# Altium schematic sheet [16] - PS BANKS 500-502.SchDoc("[16] - PS BANKS 500-502")
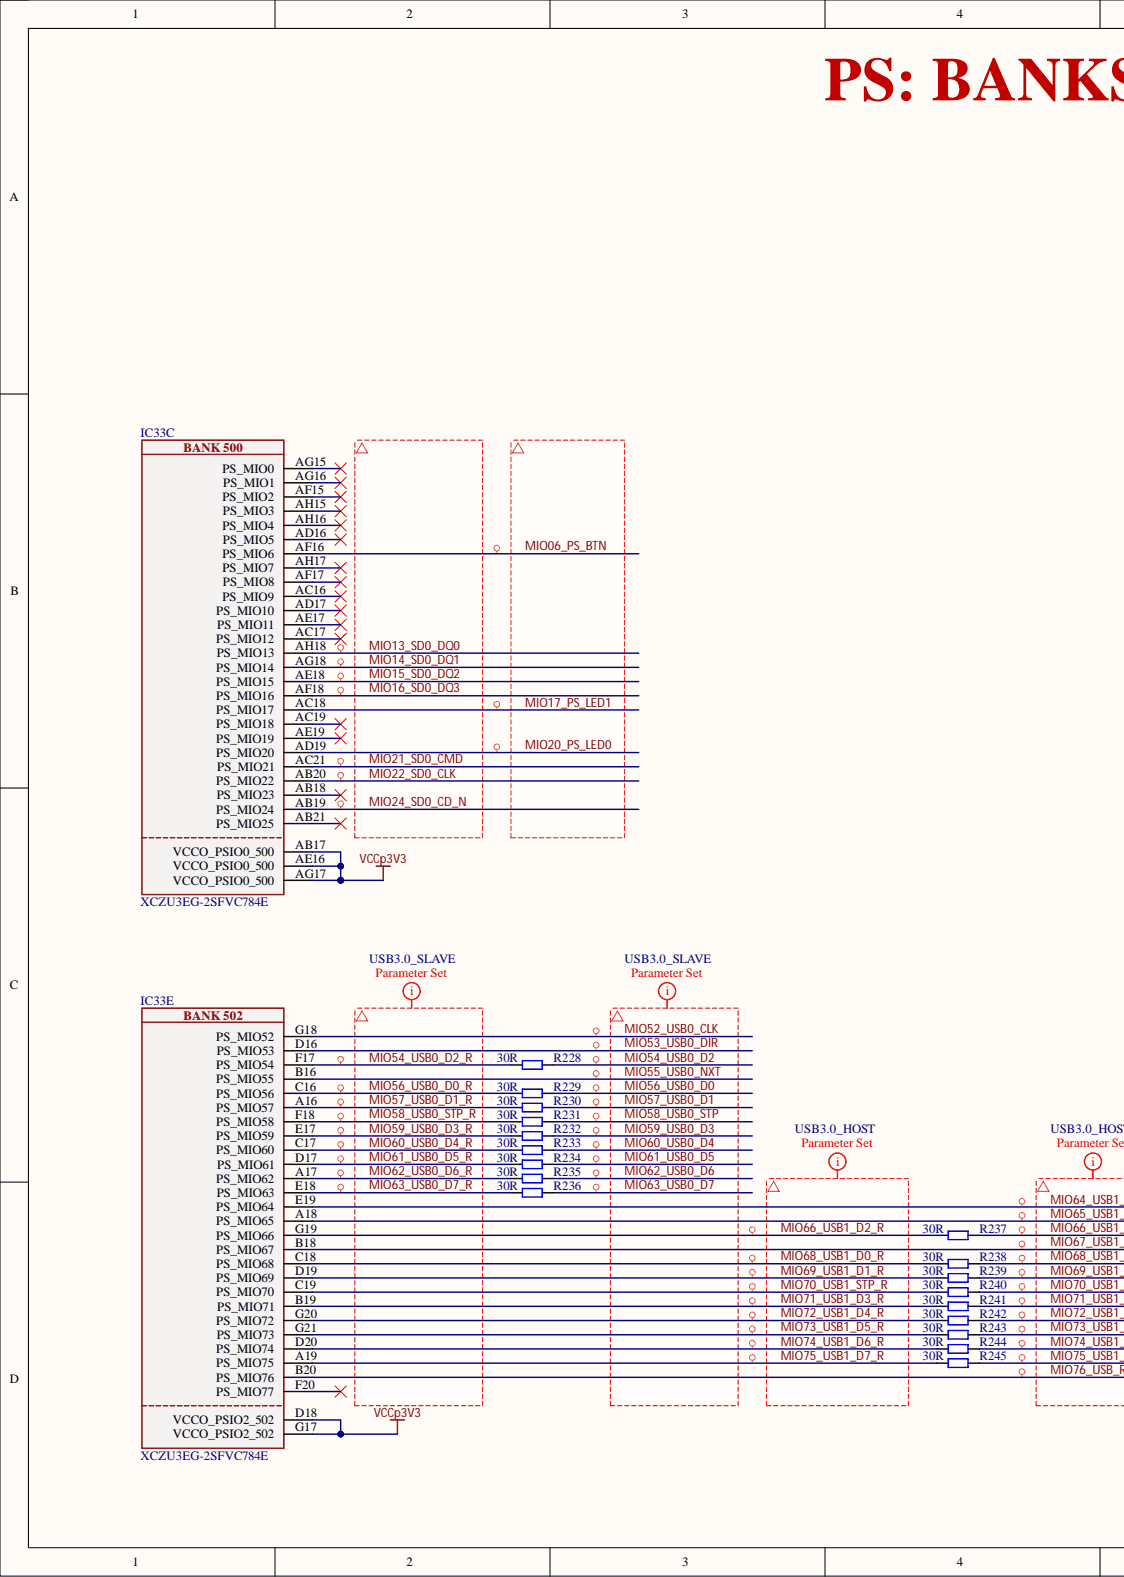
[diagram p0: [16] - PS BANKS 500-502.SchDoc, left]
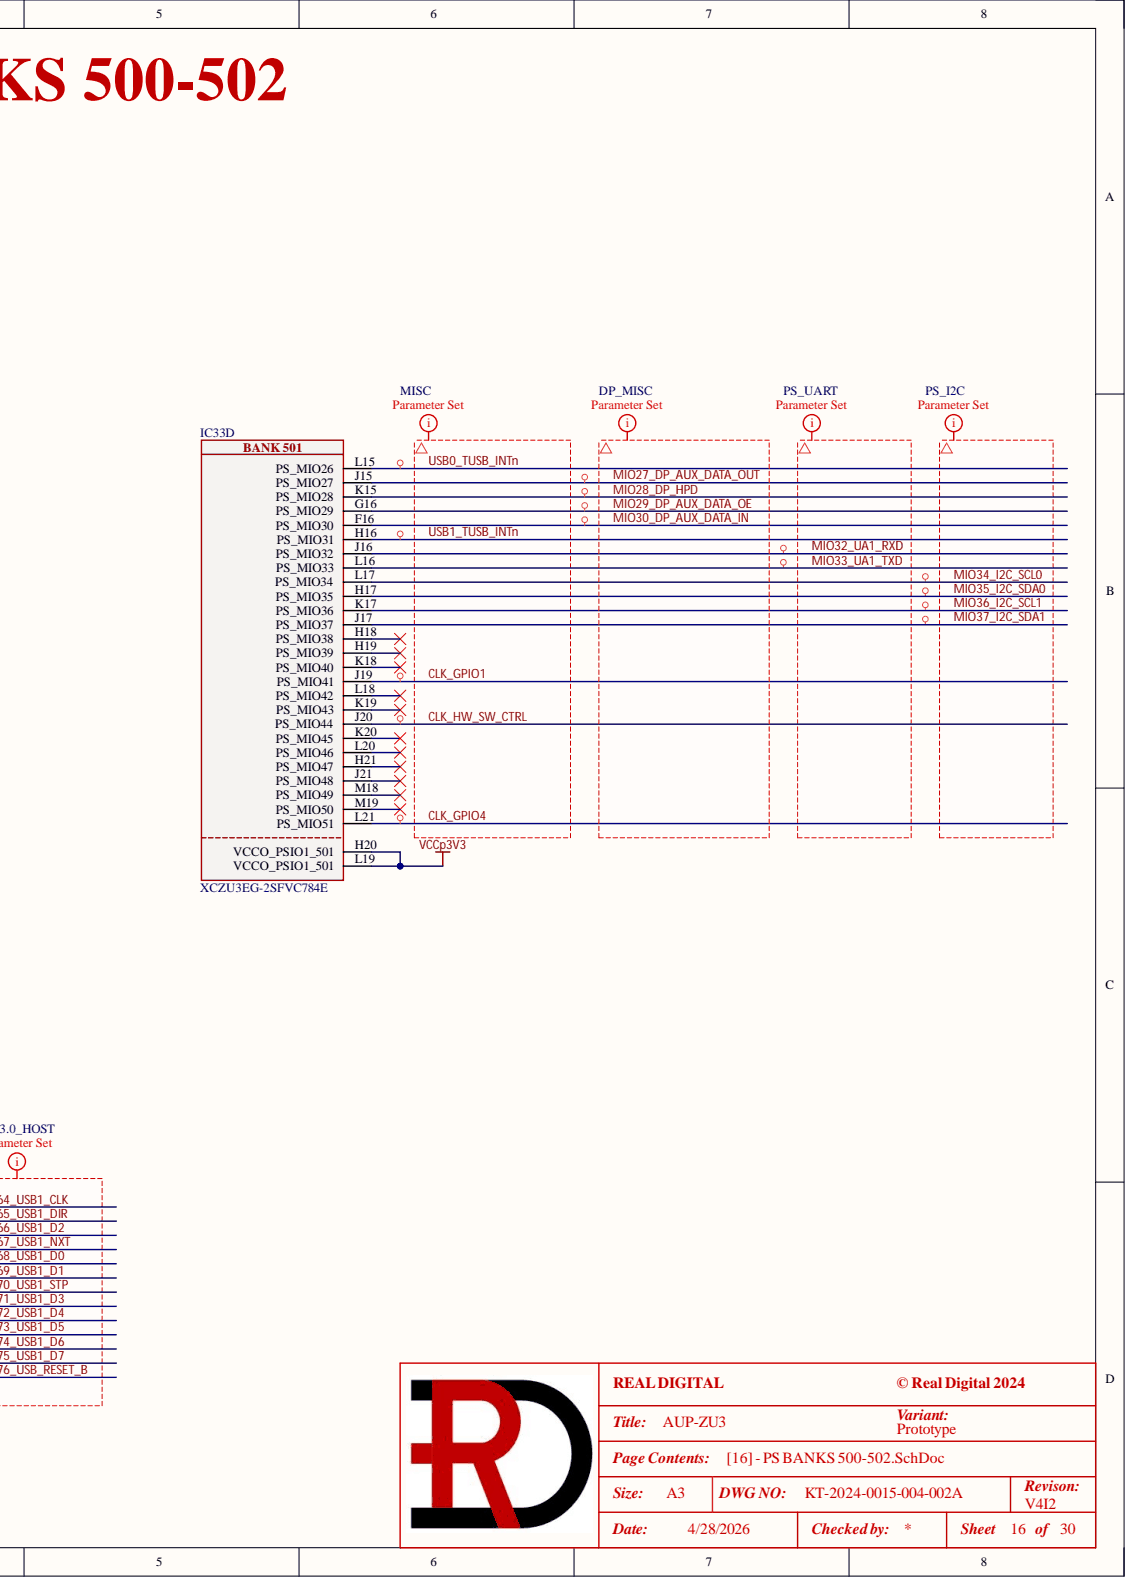
[diagram p1: [16] - PS BANKS 500-502.SchDoc, right]

## Parts
IC33  XCZU3EG-2SFVC784E  [SFVC784 - AMD]  (parts C,D,E)
R228  30R  [R0201]
R229  30R  [R0201]
R230  30R  [R0201]
R231  30R  [R0201]
R232  30R  [R0201]
R233  30R  [R0201]
R234  30R  [R0201]
R235  30R  [R0201]
R236  30R  [R0201]
R237  30R  [R0201]
R238  30R  [R0201]
R239  30R  [R0201]
R240  30R  [R0201]
R241  30R  [R0201]
R242  30R  [R0201]
R243  30R  [R0201]
R244  30R  [R0201]
R245  30R  [R0201]

## Nets
VCCp3V3: IC33.AB17(VCCO_PSIO0_500) IC33.AE16(VCCO_PSIO0_500) IC33.AG17(VCCO_PSIO0_500) IC33.D18(VCCO_PSIO2_502) IC33.G17(VCCO_PSIO2_502) IC33.H20(VCCO_PSIO1_501) IC33.L19(VCCO_PSIO1_501)
CLK_GPIO1: IC33.J19(PS_MIO41)
CLK_GPIO4: IC33.L21(PS_MIO51)
CLK_HW_SW_CTRL: IC33.J20(PS_MIO44)
MIO06_PS_BTN: IC33.AF16(PS_MIO6)
MIO13_SD0_DQ0: IC33.AH18(PS_MIO13)
MIO14_SD0_DQ1: IC33.AG18(PS_MIO14)
MIO15_SD0_DQ2: IC33.AE18(PS_MIO15)
MIO16_SD0_DQ3: IC33.AF18(PS_MIO16)
MIO17_PS_LED1: IC33.AC18(PS_MIO17)
MIO20_PS_LED0: IC33.AD19(PS_MIO20)
MIO21_SD0_CMD: IC33.AC21(PS_MIO21)
MIO22_SD0_CLK: IC33.AB20(PS_MIO22)
MIO24_SD0_CD_N: IC33.AB19(PS_MIO24)
MIO27_DP_AUX_DATA_OUT: IC33.J15(PS_MIO27)
MIO28_DP_HPD: IC33.K15(PS_MIO28)
MIO29_DP_AUX_DATA_OE: IC33.G16(PS_MIO29)
MIO30_DP_AUX_DATA_IN: IC33.F16(PS_MIO30)
MIO32_UA1_RXD: IC33.J16(PS_MIO32)
MIO33_UA1_TXD: IC33.L16(PS_MIO33)
MIO34_I2C_SCL0: IC33.L17(PS_MIO34)
MIO35_I2C_SDA0: IC33.H17(PS_MIO35)
MIO36_I2C_SCL1: IC33.K17(PS_MIO36)
MIO37_I2C_SDA1: IC33.J17(PS_MIO37)
MIO52_USB0_CLK: IC33.G18(PS_MIO52)
MIO53_USB0_DIR: IC33.D16(PS_MIO53)
MIO54_USB0_D2: R228.2
MIO54_USB0_D2_R: IC33.F17(PS_MIO54) R228.1
MIO55_USB0_NXT: IC33.B16(PS_MIO55)
MIO56_USB0_D0: R229.2
MIO56_USB0_D0_R: IC33.C16(PS_MIO56) R229.1
MIO57_USB0_D1: R230.2
MIO57_USB0_D1_R: IC33.A16(PS_MIO57) R230.1
MIO58_USB0_STP: R231.2
MIO58_USB0_STP_R: IC33.F18(PS_MIO58) R231.1
MIO59_USB0_D3: R232.2
MIO59_USB0_D3_R: IC33.E17(PS_MIO59) R232.1
MIO60_USB0_D4: R233.2
MIO60_USB0_D4_R: IC33.C17(PS_MIO60) R233.1
MIO61_USB0_D5: R234.2
MIO61_USB0_D5_R: IC33.D17(PS_MIO61) R234.1
MIO62_USB0_D6: R235.2
MIO62_USB0_D6_R: IC33.A17(PS_MIO62) R235.1
MIO63_USB0_D7: R236.2
MIO63_USB0_D7_R: IC33.E18(PS_MIO63) R236.1
MIO64_USB1_CLK: IC33.E19(PS_MIO64)
MIO65_USB1_DIR: IC33.A18(PS_MIO65)
MIO66_USB1_D2: R237.2
MIO66_USB1_D2_R: IC33.G19(PS_MIO66) R237.1
MIO67_USB1_NXT: IC33.B18(PS_MIO67)
MIO68_USB1_D0: R238.2
MIO68_USB1_D0_R: IC33.C18(PS_MIO68) R238.1
MIO69_USB1_D1: R239.2
MIO69_USB1_D1_R: IC33.D19(PS_MIO69) R239.1
MIO70_USB1_STP: R240.2
MIO70_USB1_STP_R: IC33.C19(PS_MIO70) R240.1
MIO71_USB1_D3: R241.2
MIO71_USB1_D3_R: IC33.B19(PS_MIO71) R241.1
MIO72_USB1_D4: R242.2
MIO72_USB1_D4_R: IC33.G20(PS_MIO72) R242.1
MIO73_USB1_D5: R243.2
MIO73_USB1_D5_R: IC33.G21(PS_MIO73) R243.1
MIO74_USB1_D6: R244.2
MIO74_USB1_D6_R: IC33.D20(PS_MIO74) R244.1
MIO75_USB1_D7: R245.2
MIO75_USB1_D7_R: IC33.A19(PS_MIO75) R245.1
MIO76_USB_RESET_B: IC33.B20(PS_MIO76)
USB0_TUSB_INTn: IC33.L15(PS_MIO26)
USB1_TUSB_INTn: IC33.H16(PS_MIO31)

Not connected: IC33 AB18(PS_MIO23) AB21(PS_MIO25) AC16(PS_MIO9) AC17(PS_MIO12) AC19(PS_MIO18) AD16(PS_MIO5) AD17(PS_MIO10) AE17(PS_MIO11) AE19(PS_MIO19) AF15(PS_MIO2) AF17(PS_MIO8) AG15(PS_MIO0) AG16(PS_MIO1) AH15(PS_MIO3) AH16(PS_MIO4) AH17(PS_MIO7) F20(PS_MIO77) H18(PS_MIO38) H19(PS_MIO39) H21(PS_MIO47) J21(PS_MIO48) K18(PS_MIO40) K19(PS_MIO43) K20(PS_MIO45) L18(PS_MIO42) L20(PS_MIO46) M18(PS_MIO49) M19(PS_MIO50)

## Library data of the parts
IC33 = XCZU3EG-2SFVC784E: Quad ARM® Cortex®-A53 MPCore™ with CoreSight™, Dual ARM®Cortex™-R5 with CoreSight™, ARM Mali™-400 MP2 System On Chip (SOC) IC Zynq® UltraScale+™ MPSoC EG Zynq®UltraScale+™ FPGA, 154K+ Logic Cells 533MHz, 600MHz, 1.3GHz 784-FCBGA (23x23); Manufacturer 1: AMD; .Checked_PCB: RV; C P/N: RD-126-0101-C; LoadStatus: Load; Category: IC; Component Kind: Standard; Library Name: KT-RealDigital.IntLib; Manufacturer 1 Part Number: XCZU3EG-2SFVC784E; .Checked_SCH: RV; .Creator: RV
R228-R245 = RC0201FR-0730RL: RES SMD 30 OHM 1% 1/20W 0201; Manufacturer 1: YAGEO; .Checked_PCB: RV; C P/N: RD-101-0009; LoadStatus: Load; Tolerance: 1%/TK200; Category: Resistors; Component Kind: Standard; Library Name: KT-RealDigital.IntLib; Manufacturer 1 Part Number: RC0201FR-0730RL; .Checked_SCH: RV; .Creator: RV
